# Altium schematic sheet LED_board_schematic.SchDoc
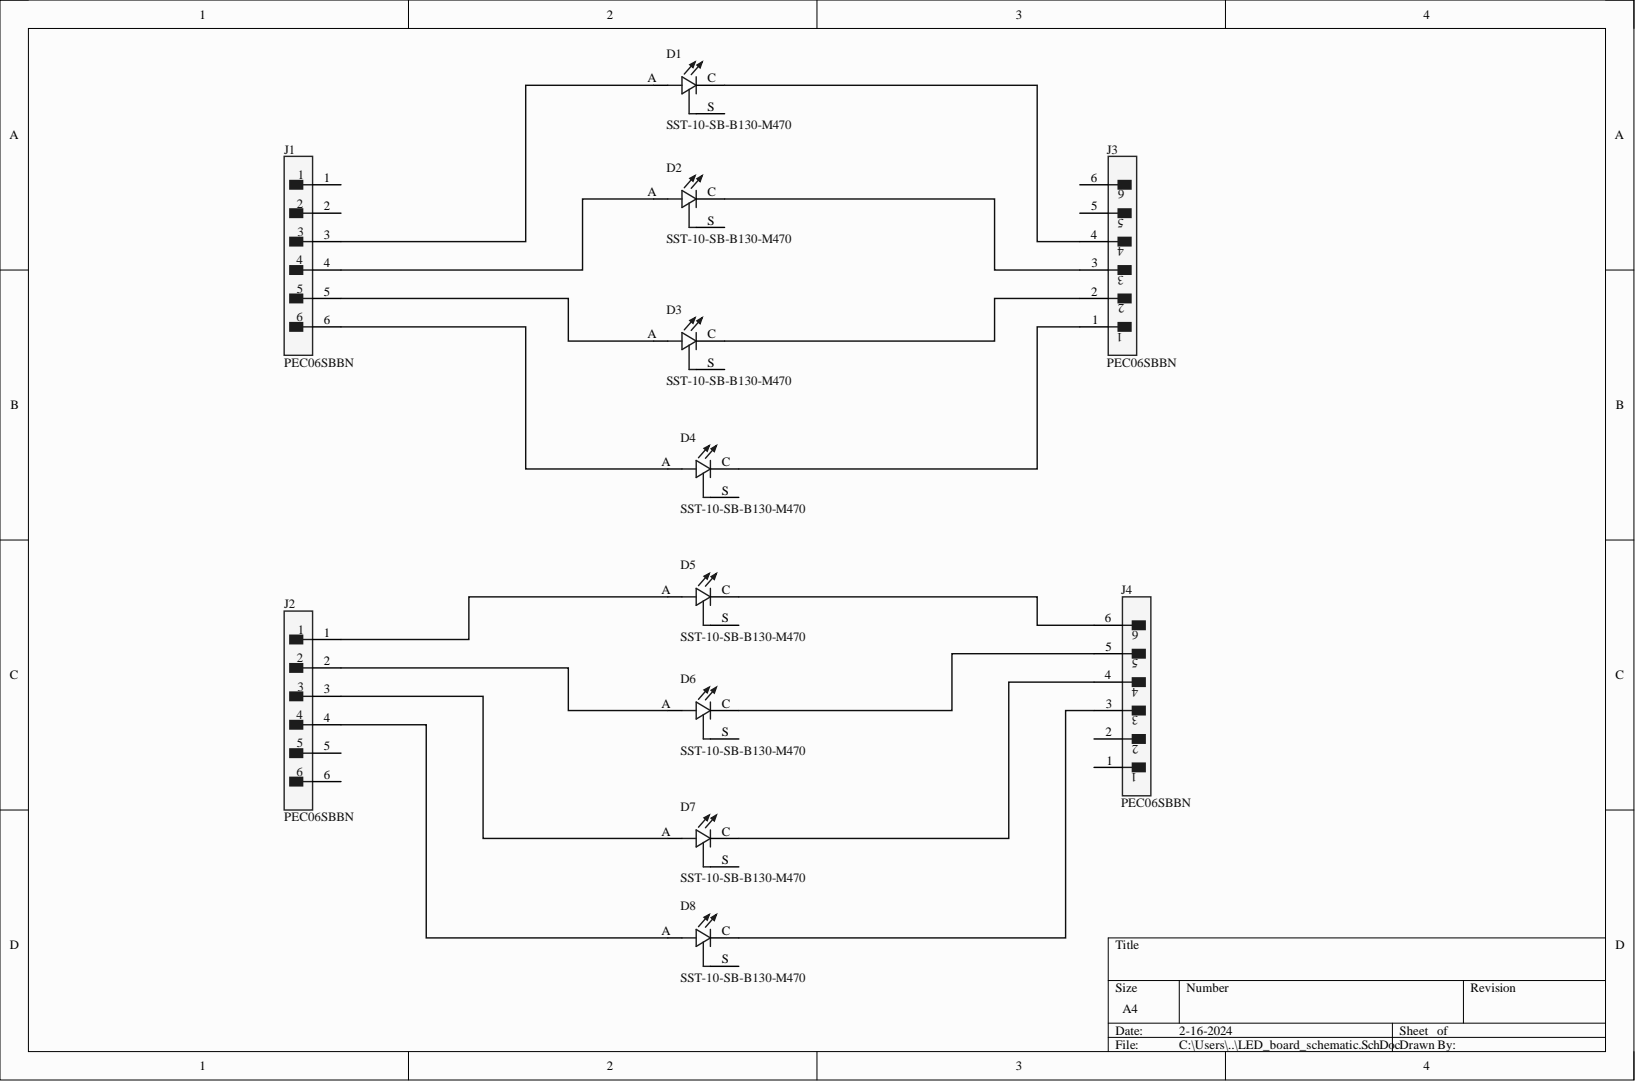
[diagram p0: LED_board_schematic.SchDoc]

## Parts
D1  SST-10-SB-B130-M470  [LED_SST-10-SB-B130-M470]
D2  SST-10-SB-B130-M470  [LED_SST-10-SB-B130-M470]
D3  SST-10-SB-B130-M470  [LED_SST-10-SB-B130-M470]
D4  SST-10-SB-B130-M470  [LED_SST-10-SB-B130-M470]
D5  SST-10-SB-B130-M470  [LED_SST-10-SB-B130-M470]
D6  SST-10-SB-B130-M470  [LED_SST-10-SB-B130-M470]
D7  SST-10-SB-B130-M470  [LED_SST-10-SB-B130-M470]
D8  SST-10-SB-B130-M470  [LED_SST-10-SB-B130-M470]
J1  PEC06SBBN  [FP-PEC06SBBN-MFG]
J2  PEC06SBBN  [FP-PEC06SBBN-MFG]
J3  PEC06SBBN  [FP-PEC06SBBN-MFG]
J4  PEC06SBBN  [FP-PEC06SBBN-MFG]

## Nets
NetD1_A: D1.A J1.3
NetD1_C: D1.C J3.4
NetD2_A: D2.A J1.4
NetD2_C: D2.C J3.3
NetD3_A: D3.A J1.5
NetD3_C: D3.C J3.2
NetD4_A: D4.A J1.6
NetD4_C: D4.C J3.1
NetD5_A: D5.A J2.1
NetD5_C: D5.C J4.6
NetD6_A: D6.A J2.2
NetD6_C: D6.C J4.5
NetD7_A: D7.A J2.3
NetD7_C: D7.C J4.4
NetD8_A: D8.A J2.4
NetD8_C: D8.C J4.3

Not connected: D1 S; D2 S; D3 S; D4 S; D5 S; D6 S; D7 S; D8 S; J1 1 2; J2 5 6; J3 5 6; J4 1 2

## Library data of the parts
J1-J4 = CMP-25680-000045-1: CONN HEADER R/A 6POS 2.54MM; Connector Type: Male Pin; Gender: Male; Number of Contacts: 6; Pitch: 0.1inch; Color: Black; Component Kind: Standard; Contact Material: Bronze; Contact Mating Length: 0.23inch; Contact Plating: Tin; Contact Type: Male Pin; Height: 3.56mm; Housing Color: Black; Housing Material: Plastic; Lead Free: Lead Free; Length: 15.2mm; Library Name: Altium Content Vault; Mount: Through Hole; Number of Positions: 6; Number of Rows: 1; Package Quantity: 1; Packaging: Bulk; RoHS Compliant: Yes; Termination: Solder; Width: 2.54mm
D1-D8 = SST-10-SB-B130-M470: Availability: Unavailable; Component Kind: Standard; Library Name: SST-10-SB-B130-M470.IntLib; MP: SST-10-SB-B130-M470; Package: None; Price: None
